# Altium schematic sheet Sheet4.SchDoc(Sheet4)
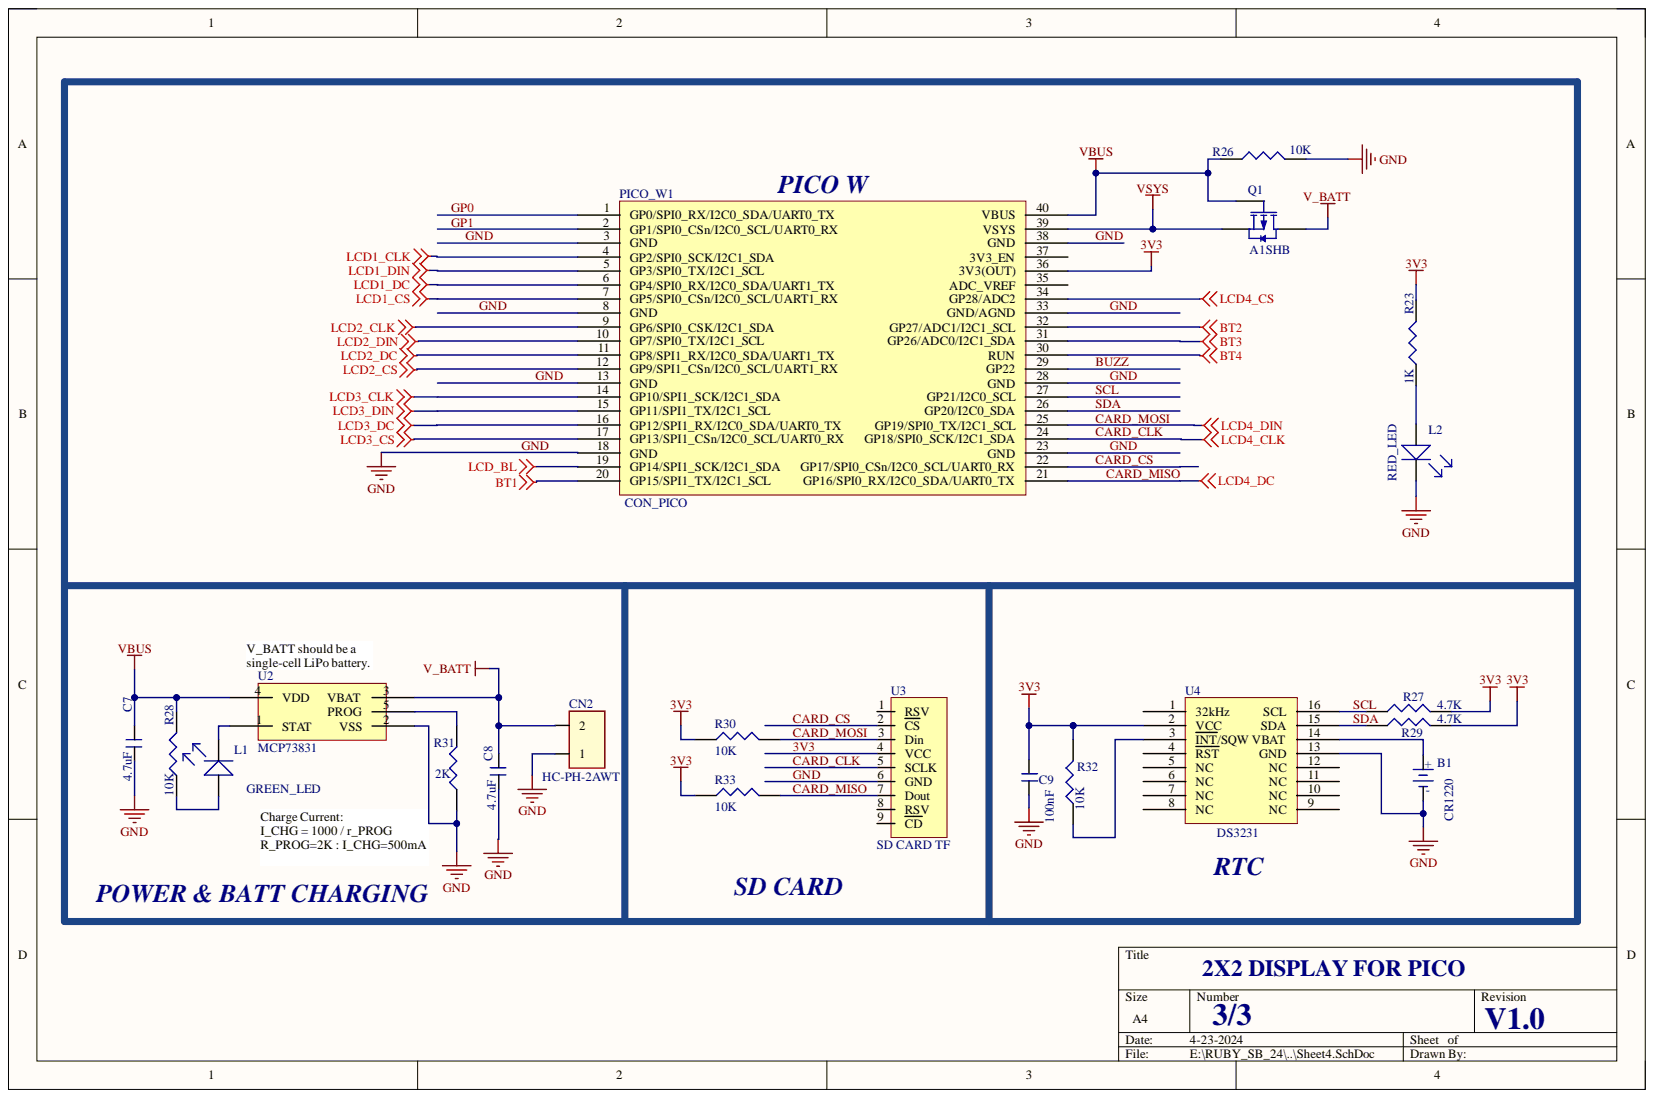
[diagram p0: Sheet4.SchDoc]

## Parts
B1  CR1220  [COIN_CELL_HOLD]
C7  4.7uF  [C_0603]
C8  4.7uF  [C_0603]
C9  100nF  [C_0603]
CN2  HC-PH-2AWT  [JST2P_P2.0mm]
L1  GREEN_LED  [LED_0603]
L2  RED_LED  [LED_0603]
PICO_W1  CON_PICO  [PICO_W]
Q1  A1SHB  [BSS84]
R23  1K  [R_0603]
R26  10K  [R_0603]
R27  4.7K  [R_0603]
R28  10K  [R_0603]
R29  4.7K  [R_0603]
R30  10K  [R_0603]
R31  2K  [R_0603]
R32  10K  [R_0603]
R33  10K  [R_0603]
U2  MCP73831  [SOT23-5]
U3  SD CARD TF  [micro sd card]
U4  DS3231  [SO_16]

## Nets
BT1: PICO_W1.20(GP15/SPI1_TX/I2C1_SCL)
BT2: PICO_W1.32(GP27/ADC1/I2C1_SCL)
BT3: PICO_W1.31(GP26/ADC0/I2C1_SDA)
BT4: PICO_W1.30(RUN)
LCD1_CLK: PICO_W1.4(GP2/SPI0_SCK/I2C1_SDA)
LCD1_CS: PICO_W1.7(GP5/SPI0_CSn/I2C0_SCL/UART1_RX)
LCD1_DC: PICO_W1.6(GP4/SPI0_RX/I2C0_SDA/UART1_TX)
LCD1_DIN: PICO_W1.5(GP3/SPI0_TX/I2C1_SCL)
LCD2_CLK: PICO_W1.9(GP6/SPI0_CSK/I2C1_SDA)
LCD2_CS: PICO_W1.12(GP9/SPI1_CSn/I2C0_SCL/UART1_RX)
LCD2_DC: PICO_W1.11(GP8/SPI1_RX/I2C0_SDA/UART1_TX)
LCD2_DIN: PICO_W1.10(GP7/SPI0_TX/I2C1_SCL)
LCD3_CLK: PICO_W1.14(GP10/SPI1_SCK/I2C1_SDA)
LCD3_CS: PICO_W1.17(GP13/SPI1_CSn/I2C0_SCL/UART0_RX)
LCD3_DC: PICO_W1.16(GP12/SPI1_RX/I2C0_SDA/UART0_TX)
LCD3_DIN: PICO_W1.15(GP11/SPI1_TX/I2C1_SCL)
LCD4_CS: PICO_W1.34(GP28/ADC2)
LCD_BL: PICO_W1.19(GP14/SPI1_SCK/I2C1_SDA)
V_BATT: C8.1 CN2.2 Q1.3 U2.3(VBAT)
VBUS: C7.1 PICO_W1.40(VBUS) Q1.1 R26.1 R28.2 U2.4(VDD)
VSYS: PICO_W1.39(VSYS) Q1.2
3V3: C9.2 PICO_W1.36(3V3(OUT)) R23.2 R27.2 R29.2 R30.1 R32.2 R33.1 U3.4(VCC) U4.2(VCC)
BUZZ: PICO_W1.29(GP22)
CARD_CLK: PICO_W1.24(GP18/SPI0_SCK/I2C1_SDA) U3.5(SCLK)
CARD_CS: PICO_W1.22(GP17/SPI0_CSn/I2C0_SCL/UART0_RX) U3.2(CS)
CARD_MISO: PICO_W1.21(GP16/SPI0_RX/I2C0_SDA/UART0_TX) R33.2 U3.7(Dout)
CARD_MOSI: PICO_W1.25(GP19/SPI0_TX/I2C1_SCL) R30.2 U3.3(Din)
GND: B1.K C7.2 C8.2 C9.1 CN2.1 L2.K PICO_W1.3(GND) PICO_W1.8(GND) PICO_W1.13(GND) PICO_W1.18(GND) PICO_W1.23(GND) PICO_W1.28(GND) PICO_W1.33(GND/AGND) PICO_W1.38(GND) R26.2 R31.1 U2.2(VSS) U3.6(GND) U4.13(GND)
GP0: PICO_W1.1(GP0/SPI0_RX/I2C0_SDA/UART0_TX)
GP1: PICO_W1.2(GP1/SPI0_CSn/I2C0_SCL/UART0_RX)
SCL: PICO_W1.27(GP21/I2C0_SCL) R27.1 U4.16(SCL)
SDA: PICO_W1.26(GP20/I2C0_SDA) R29.1 U4.15(SDA)
NetB1_A: B1.A U4.14(VBAT)
NetL1_A: L1.A R28.1
NetL1_K: L1.K U2.1(STAT)
NetL2_A: L2.A R23.1
NetR31_2: R31.2 U2.5(PROG)
NetR32_1: R32.1 U4.3(INT/SQW)

Not connected: PICO_W1 35(ADC_VREF) 37(3V3_EN); U3 1(RSV) 8(RSV) 9(CD); U4 1(32kHz) 4(RST) 5(NC) 6(NC) 7(NC) 8(NC) 9(NC) 10(NC) 11(NC) 12(NC)

## Library data of the parts
B1 = BATTERY: Component Kind: Standard; Library Name: dxp_pasl_lib.IntLib
C7-C9 = CAP_NONPOLAR: Component Kind: Standard; Library Name: dxp_pasl_lib.IntLib
CN2 = CON2: Component Kind: Standard; Library Name: dxp_pasl_lib.IntLib
L1 L2 = LED: Component Kind: Standard; Library Name: dxp_pasl_lib.IntLib
PICO_W1 = CON40_PICO: Component Kind: Standard; Library Name: dxp_pasl_lib.IntLib
Q1 = BSS84: 50V 130mA 250mW 10Ω 10V,130mA 2V 1mA P Channel SOT-23(SOT-23-3) MOSFETs ROHS #C493579; PackageDocument: 1995/1996; CATEGORY: MOSFET; Component Kind: Standard; LatestRevisionDate: 17-Jul-2002; LatestRevisionNote: Re-released for DXP Platform.; PackageReference: E3; Published: 8-Jun-2000; Library Name: dxp_pasl_lib.IntLib; Publisher: Altium Limited
R23 R26-R33 = RES: Component Kind: Standard; Library Name: dxp_pasl_lib.IntLib
U3 = SD CARD TF: Micro SD Memory Card Socket; Component Kind: Standard; Library Name: dxp_pasl_lib.IntLib
U4 = DS3231_1: Extremely Accurate I2C-Integrated  RTC; Component Kind: Standard; Library Name: dxp_pasl_lib.IntLib
U2 = MCP73831: Component Kind: Standard; Library Name: dxp_pasl_lib.IntLib
